# Altium schematic sheet 11_BREAK_OFF.SchDoc(11_BREAK_OFF)
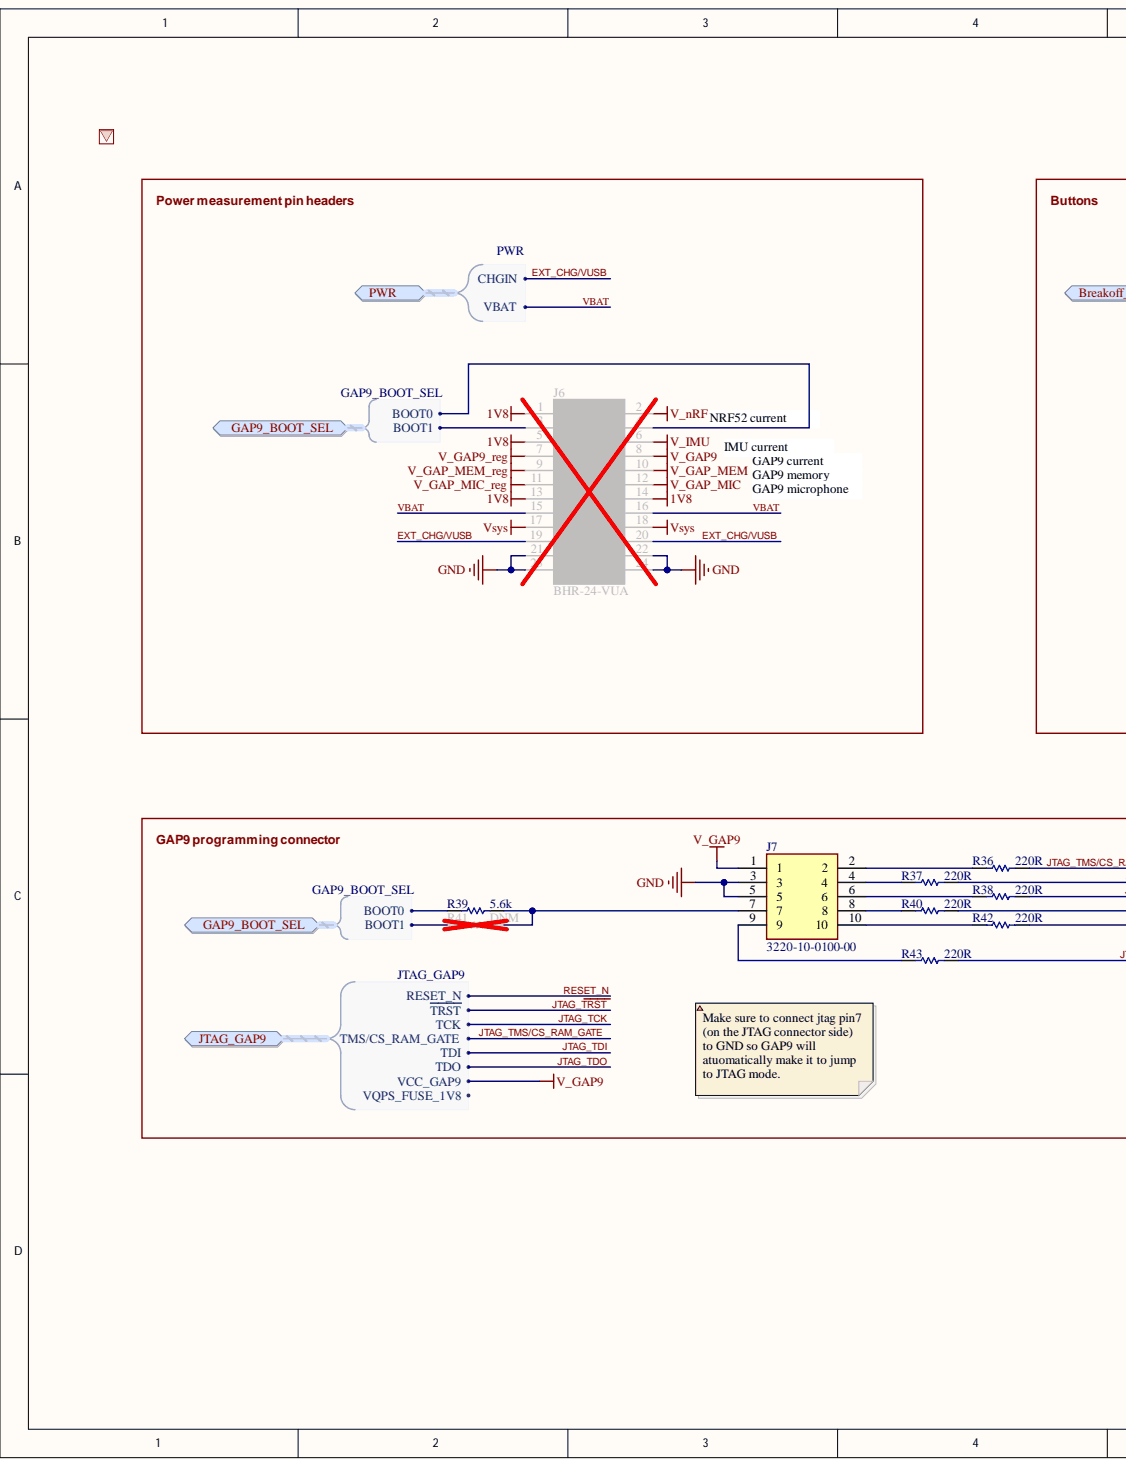
[diagram p0: 11_BREAK_OFF.SchDoc, left]
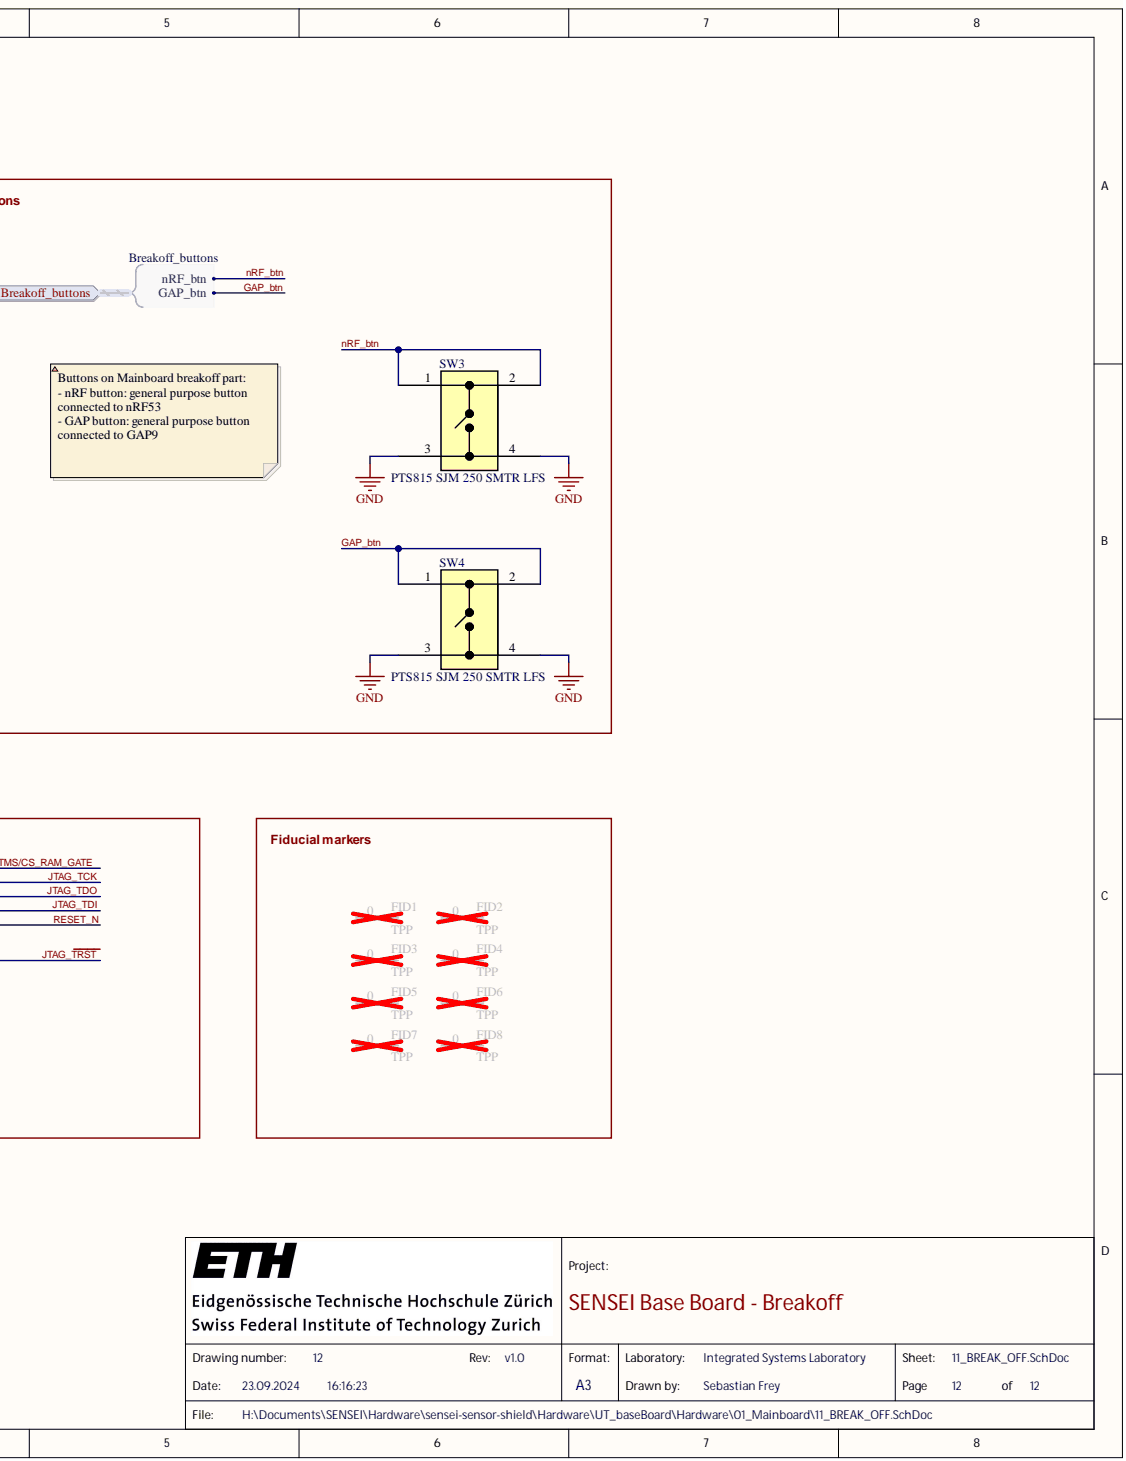
[diagram p1: 11_BREAK_OFF.SchDoc, right]

## Parts
FID1  TPP  [TPP]
FID2  TPP  [TPP]
FID3  TPP  [TPP]
FID4  TPP  [TPP]
FID5  TPP  [TPP]
FID6  TPP  [TPP]
FID7  TPP  [TPP]
FID8  TPP  [TPP]
J6  BHR-24-VUA  [SHDR24W64P254_2X12_3808X880X910P]
J7  3220-10-0100-00  [322010010000]
R36  value 220R  [RESC0603X28X15ML05T05]  (hidden comment: CRCW0201220RFKED)
R37  value 220R  [RESC0603X28X15ML05T05]  (hidden comment: CRCW0201220RFKED)
R38  value 220R  [RESC0603X28X15ML05T05]  (hidden comment: CRCW0201220RFKED)
R39  Resistance: 5.6k  [RESC1608X55X30ML20T10]  (hidden comment: RK73B1JTTD562J)
R40  value 220R  [RESC0603X28X15ML05T05]  (hidden comment: CRCW0201220RFKED)
R41  DNM  [RESC1608X55X30ML20T10]
R42  value 220R  [RESC0603X28X15ML05T05]  (hidden comment: CRCW0201220RFKED)
R43  value 220R  [RESC0603X28X15ML05T05]  (hidden comment: CRCW0201220RFKED)
SW3  PTS815 SJM 250 SMTR LFS  [SW4_PTS815 SJM 250 SMTR LFS_CNK]
SW4  PTS815 SJM 250 SMTR LFS  [SW4_PTS815 SJM 250 SMTR LFS_CNK]

## Ports
Breakoff_buttons, Breakoff_buttons.GAP_btn, Breakoff_buttons.nRF_btn, GAP9_BOOT_SEL, GAP9_BOOT_SEL.BOOT0, GAP9_BOOT_SEL.BOOT1, JTAG_GAP9, JTAG_GAP9.RESET_N, JTAG_GAP9.TCK, JTAG_GAP9.TDI, JTAG_GAP9.TDO, JTAG_GAP9.TMS/CS_RAM_GATE, JTAG_GAP9.VCC_GAP9, JTAG_GAP9.VQPS_FUSE_1V8, JTAG_GAP9.~{TRST}, PWR, PWR.CHGIN, PWR.VBAT

## Nets
1V8: J6.1 J6.5 J6.13 J6.14
GND: J6.21 J6.22 J6.23 J6.24 J7.3 J7.5 SW3.3 SW3.4 SW4.3 SW4.4
V_GAP9_reg: J6.7
V_GAP_MEM: J6.10
V_GAP_MEM_reg: J6.9
V_GAP_MIC: J6.12
V_GAP_MIC_reg: J6.11
V_IMU: J6.6
V_nRF: J6.2
Vsys: J6.17 J6.18
EXT_CHG/VUSB: J6.19 J6.20 ; port PWR
GAP_btn: SW4.1 SW4.2 ; port Breakoff_buttons
JTAG_~{TRST}: R43.2 ; port JTAG_GAP9
JTAG_TCK: R37.2 ; port JTAG_GAP9
JTAG_TDI: R40.2 ; port JTAG_GAP9
JTAG_TDO: R38.2 ; port JTAG_GAP9
JTAG_TMS/CS_RAM_GATE: R36.2 ; port JTAG_GAP9
nRF_btn: SW3.1 SW3.2 ; port Breakoff_buttons
RESET_N: R42.2 ; port JTAG_GAP9
V_GAP9: J6.8 J7.1 ; port JTAG_GAP9
VBAT: J6.15 J6.16 ; port PWR
NetJ6_3: J6.3 R41.1 ; port GAP9_BOOT_SEL
NetJ6_4: J6.4 R39.1 ; port GAP9_BOOT_SEL
NetJ7_2: J7.2 R36.1
NetJ7_4: J7.4 R37.1
NetJ7_6: J7.6 R38.1
NetJ7_7: J7.7 R39.2 R41.2
NetJ7_8: J7.8 R40.1
NetJ7_9: J7.9 R43.1
NetJ7_10: J7.10 R42.1
N00027: ; port JTAG_GAP9

Not connected: FID1 0; FID2 0; FID3 0; FID4 0; FID5 0; FID6 0; FID7 0; FID8 0

## Library data of the parts
J6 = BHR-24-VUA: Connector; Manufacturer_Name: Adam Tech; Arrow Part Number: BHR-24-VUA; Arrow Price/Stock: https://www.arrow.com/en/products/bhr-24-vua/adam-tech; Component Kind: Standard; Datasheet Link: https://datasheet.datasheetarchive.com/originals/distributors/Datasheets_SAMA/695a8166aa40898d0a6b5857fa89f6fe.pdf; Height: 9.1mm; Manufacturer_Part_Number: BHR-24-VUA
J7 = 3220-10-0100-00: Connector; Manufacturer_Name: CNC Tech; Arrow Part Number: 3220-10-0100-00; Arrow Price/Stock: https://www.arrow.com/en/products/3220-10-0100-00/cnc-tech-llc?region=nac; Component Kind: Standard; Datasheet Link: https://datasheet.datasheetarchive.com/originals/dk/DKDS-7/133059.pdf; Height: 5.6mm; Manufacturer_Part_Number: 3220-10-0100-00
R36-R38 R40 R42 R43 = CMP-2004-00982-1: Manufacturer: Vishay Dale; Component Kind: Standard; Library Name: Altium Content Vault; Part Number: CRCW0201220RFKED
R39 = CMP-2000-01007-1: Manufacturer: KOA Speer; Component Kind: Standard; Library Name: Altium Content Vault; Part Number: RK73B1JTTD562J
R41 = CMP-2000-01007-1: Manufacturer: KOA Speer; Part Number: RK73B1JTTD562J; Component Kind: Standard; Library Name: Altium Content Vault; Resistance: DNM
SW3 SW4 = PTS815 SJM 250 SMTR LFS: No Description Available; Mfr_Name: C&K Components; Component Kind: Standard; Library Name: EEG_SchLib.SchLib; Manufacturer_Part_Number: PTS815 SJM 250 SMTR LFS; RefDes: RefDes; Type: DEV; Copyright: Copyright (C) 2023 Ultra Librarian. All rights reserved.
FID1-FID8 = TPP: Component Kind: Standard; Library Name: EEG_SchLib.SchLib
